# Altium schematic sheet can_transceiver.SchDoc
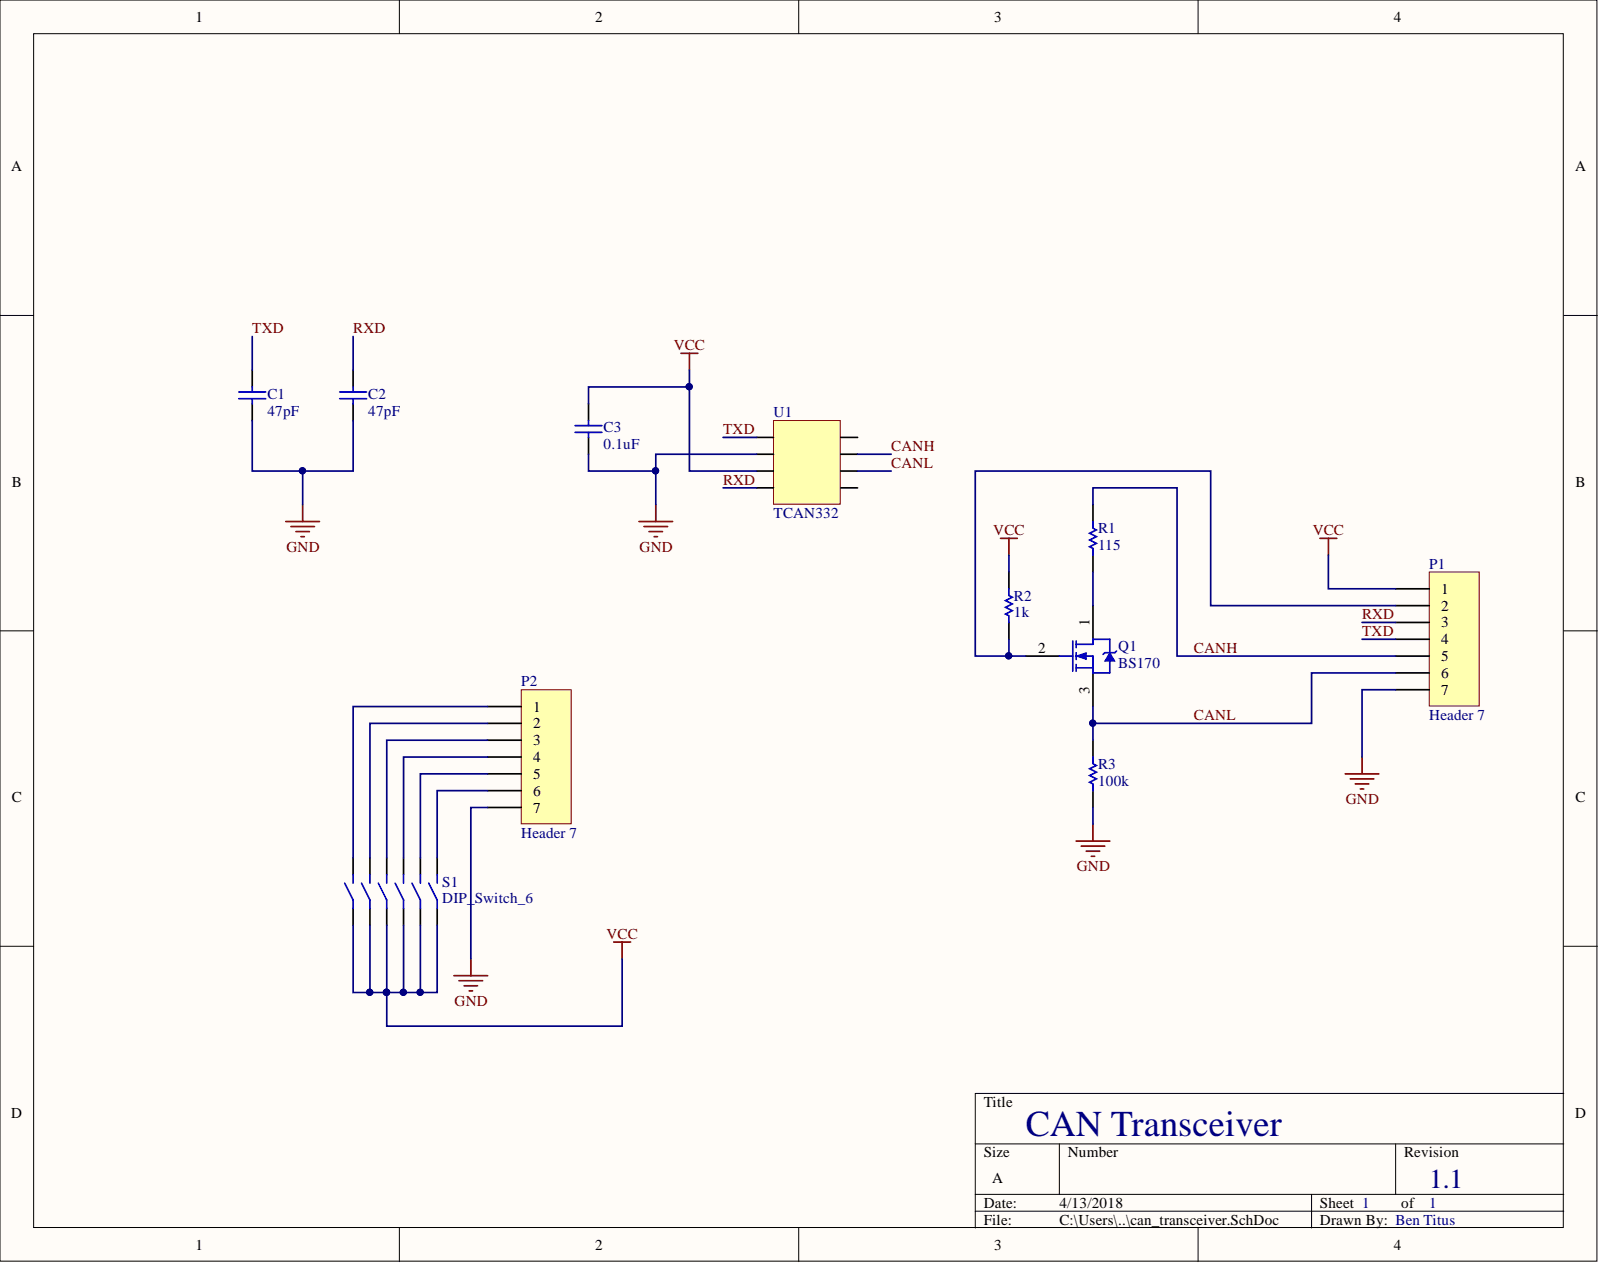
[diagram p0: can_transceiver.SchDoc]

## Parts
C1  47pF  [CAPC0805(2012)145_L]
C2  47pF  [CAPC0805(2012)145_L]
C3  0.1uF  [CAPC0805(2012)145_L]
P1  Header 7  [HDR1X7]
P2  Header 7  [HDR1X7]
Q1  BS170  [ONSC-TO-92-3-29-11]
R1  115  [RESC0805(2012)_L]
R2  1k  [RESC0805(2012)_L]
R3  100k  [RESC0805(2012)_L]
S1  DIP_Switch_6  [SOP12]
U1  TCAN332  [SOP8]

## Nets
GND: C1.1 C2.1 C3.1 P1.7 P2.7 R3.1 U1.2
VCC: C3.2 P1.1 R2.2 S1.1 S1.2 S1.3 S1.4 S1.5 S1.6 U1.3
CANH: P1.5 R1.2 U1.7
CANL: P1.6 Q1.3 R3.2 U1.6
RXD: C2.2 P1.3 U1.4
TXD: C1.2 P1.4 U1.1
NetP1_2: P1.2 Q1.2 R2.1
NetP2_1: P2.1 S1.12
NetP2_2: P2.2 S1.11
NetP2_3: P2.3 S1.10
NetP2_4: P2.4 S1.9
NetP2_5: P2.5 S1.8
NetP2_6: P2.6 S1.7
NetQ1_1: Q1.1 R1.1

Not connected: U1 5 8

## Library data of the parts
C1-C3 = CMP-1590-00003-1: 0805 (2012 Metric) Chip Capacitor; Case-EIA: 0805; Case-Metric: 2012; Component Kind: Standard; Library Name: Altium Content Vault; PackageReference: CAPC0805(2012)145
P1 P2 = Header 7: Header, 7-Pin; Component Kind: Standard; LatestRevisionDate: 17-Jul-2002; LatestRevisionNote: Re-released for DXP Platform.; Library Name: Miscellaneous Connectors.IntLib; Signal Integrity: Connector; Publisher: Altium Limited
Q1 = BS170: Small Signal MOSFET, 500 mA, 60 V, N-Channel, 3-Pin TO-92, Bulk Box; Manufacturer: On Semiconductor; Channel Polarity: N Channel; Configuration: Single; ID Max (A): 0.5; PD Max (W): 0.35; V(BR)DSS Min (V): 60; VGS(th) Max (V): 3; VGS Max (V): 20; Component Kind: Standard; ComponentLink1Description: Manufacturer URL; ComponentLink1URL: http://www.onsemi.com/; ComponentLink2Description: Datasheet; ComponentLink2URL: http://www.onsemi.com/pub_link/Collateral/BS170-D.PDF; ComponentLink3Description: Package Specification; ComponentLink3URL: http://www.onsemi.cn/pub_link/Collateral/2N6426-D.PDF; DatasheetVersion: Rev. 6; Library Name: ON Semi MOSFETs.IntLib; Mounting Technology: Through Hole; PackageDescription: 3-Pin Transistor Outline, Body 4.825 x 3.685 mm, Pitch 1.27 mm; PackageReference: TO-92-3-29-11_AM_SL; Package Type: TO-92; PackageVersion: Rev. AM; Packing: Bulk Box; PartNumber: BS170; Supplier 1: Digi-Key; Supplier Part Number 1: BS170OS-ND
R1-R3 = CMP-1591-00002-1: 0805 (2012 Metric) Chip Resistor; Case-EIA: 0805; Case-Metric: 2012; Component Kind: Standard; Library Name: Altium Content Vault; PackageReference: RESC0805(2012)
S1 = DIP_Switch_6: 6 pin DIP switch; Component Kind: Standard; Library Name: Custom_Lib.IntLib
U1 = TCAN332: 3.3V CAN Transceiver; Component Kind: Standard; Library Name: Custom_Lib.IntLib
